# Altium schematic sheet Power.SchDoc(Power)
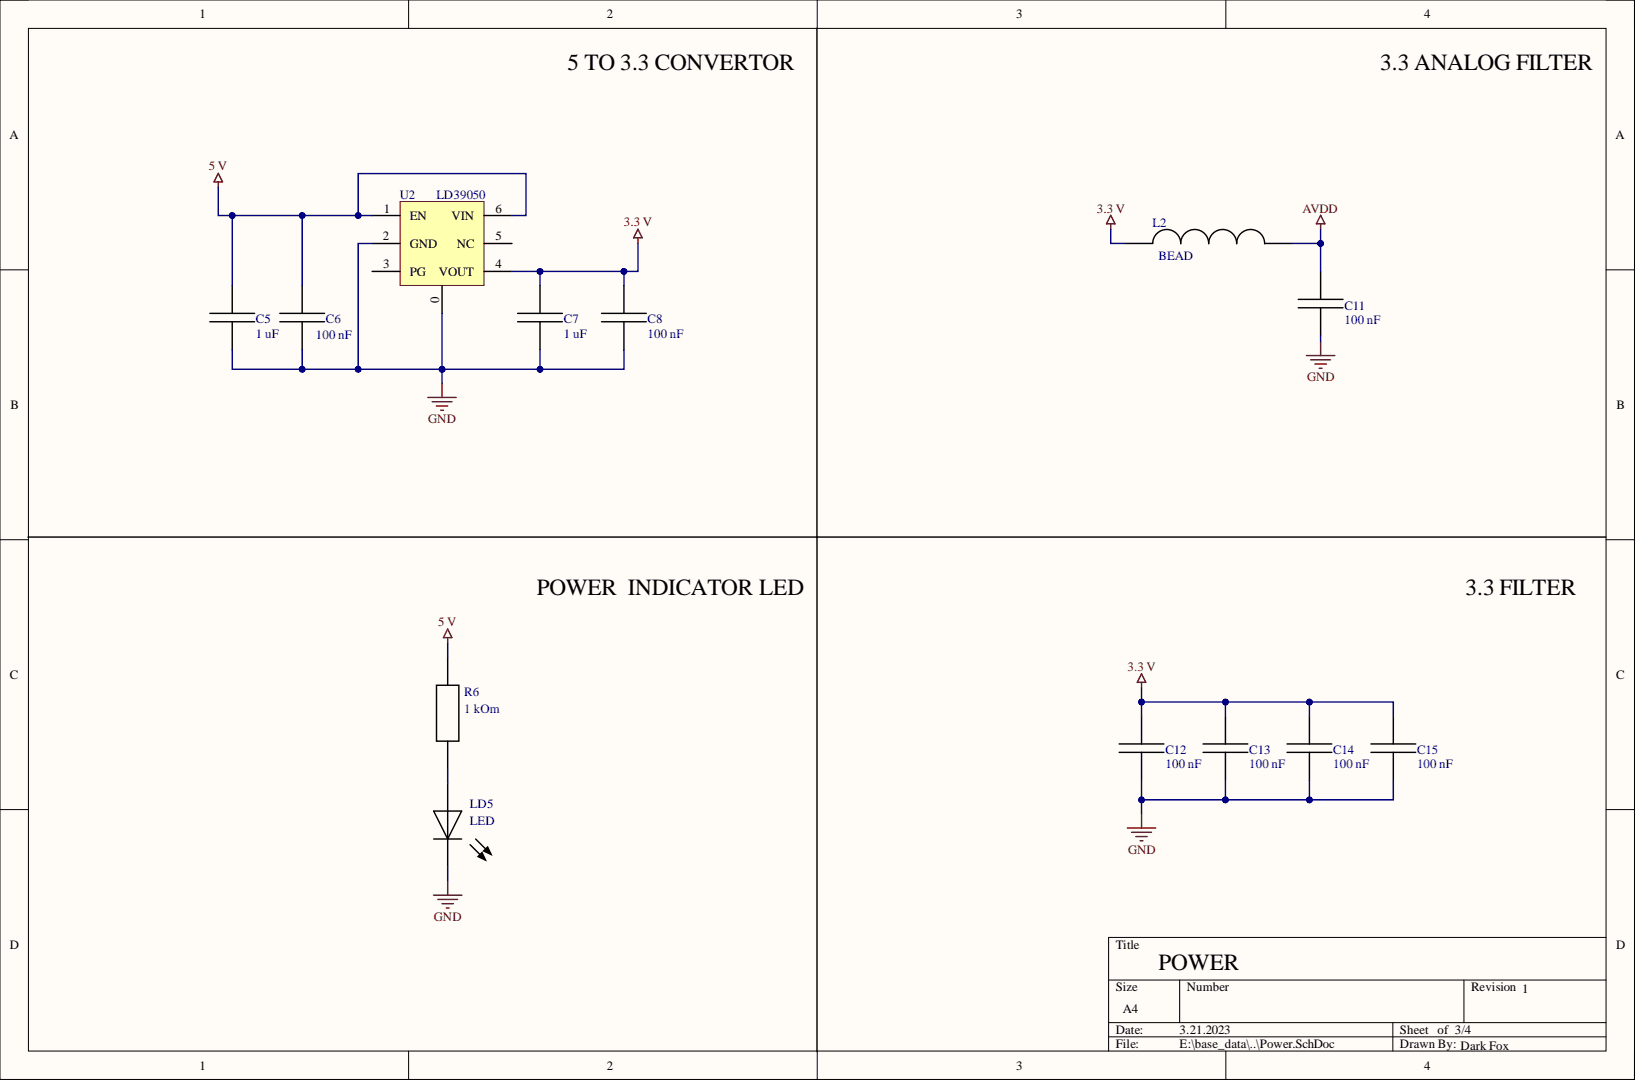
[diagram p0: Power.SchDoc]

## Parts
C5  1 uF  [0603]
C6  100 nF  [0603]
C7  1 uF  [0603]
C8  100 nF  [0603]
C11  100 nF  [0603]
C12  100 nF  [0603]
C13  100 nF  [0603]
C14  100 nF  [0603]
C15  100 nF  [0603]
L2  100 mH  [0603]  (value not drawn)
LD5  LED  [0603]
R6  1 kOm  [0603]
U2  LD39050  [DFN6]

## Nets
3.3 V: C7.1 C8.1 C12.2 C13.2 C14.2 C15.2 L2.1 U2.4(VOUT)
5 V: C5.1 C6.1 R6.2 U2.1(EN) U2.6(VIN)
AVDD: C11.2 L2.2
GND: C5.2 C6.2 C7.2 C8.2 C11.1 C12.1 C13.1 C14.1 C15.1 LD5.2 U2.0 U2.2(GND)
NetLD5_1: LD5.1 R6.1

Not connected: U2 3(PG) 5(NC)

## Library data of the parts
C5-C8 C11-C15 = Cup: Component Kind: Standard; Library Name: MyLib.SchLib
L2 = Inductor: Component Kind: Standard; Library Name: MyLib.SchLib
R6 = Res: Component Kind: Standard; Library Name: MyLib.SchLib
U2 = LD39050: voltage regulator; Component Kind: Standard; Library Name: MyLib.SchLib
LD5 = LED: Component Kind: Standard; Library Name: MyLib.SchLib
